# Altium schematic sheet 15-M2_GNSS.SchDoc(15-M2_GNSS)
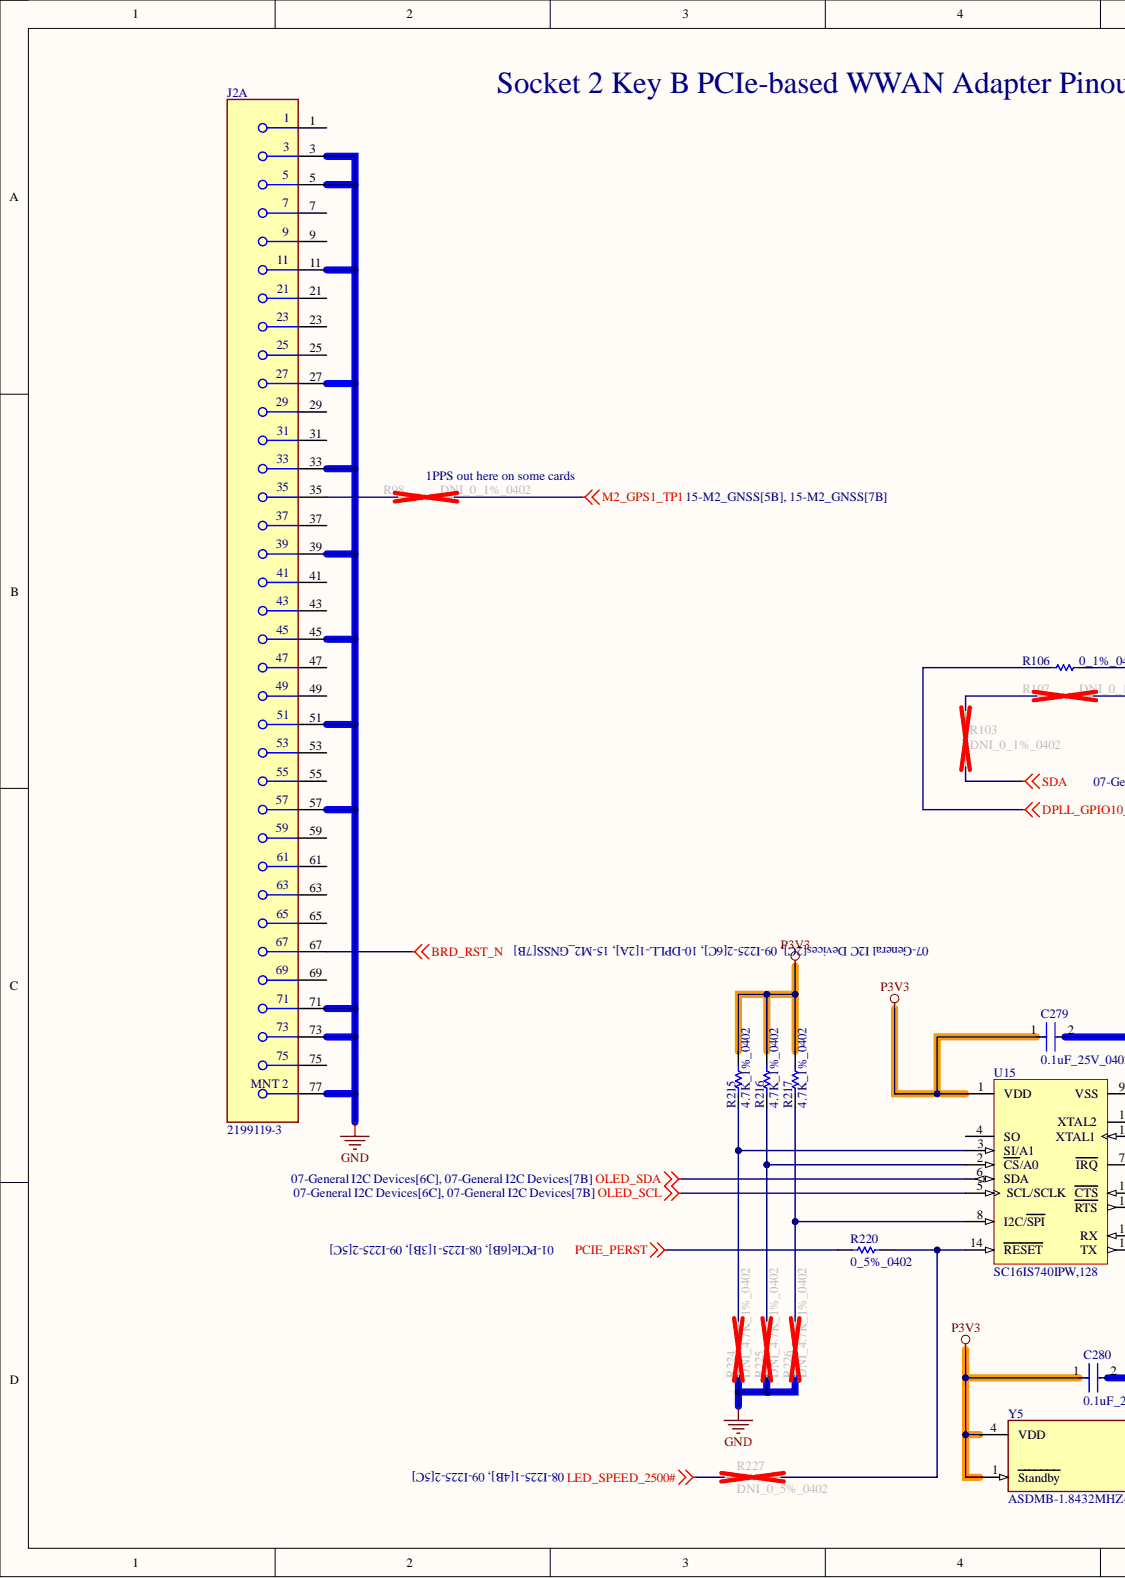
[diagram p0: 15-M2_GNSS.SchDoc, left]
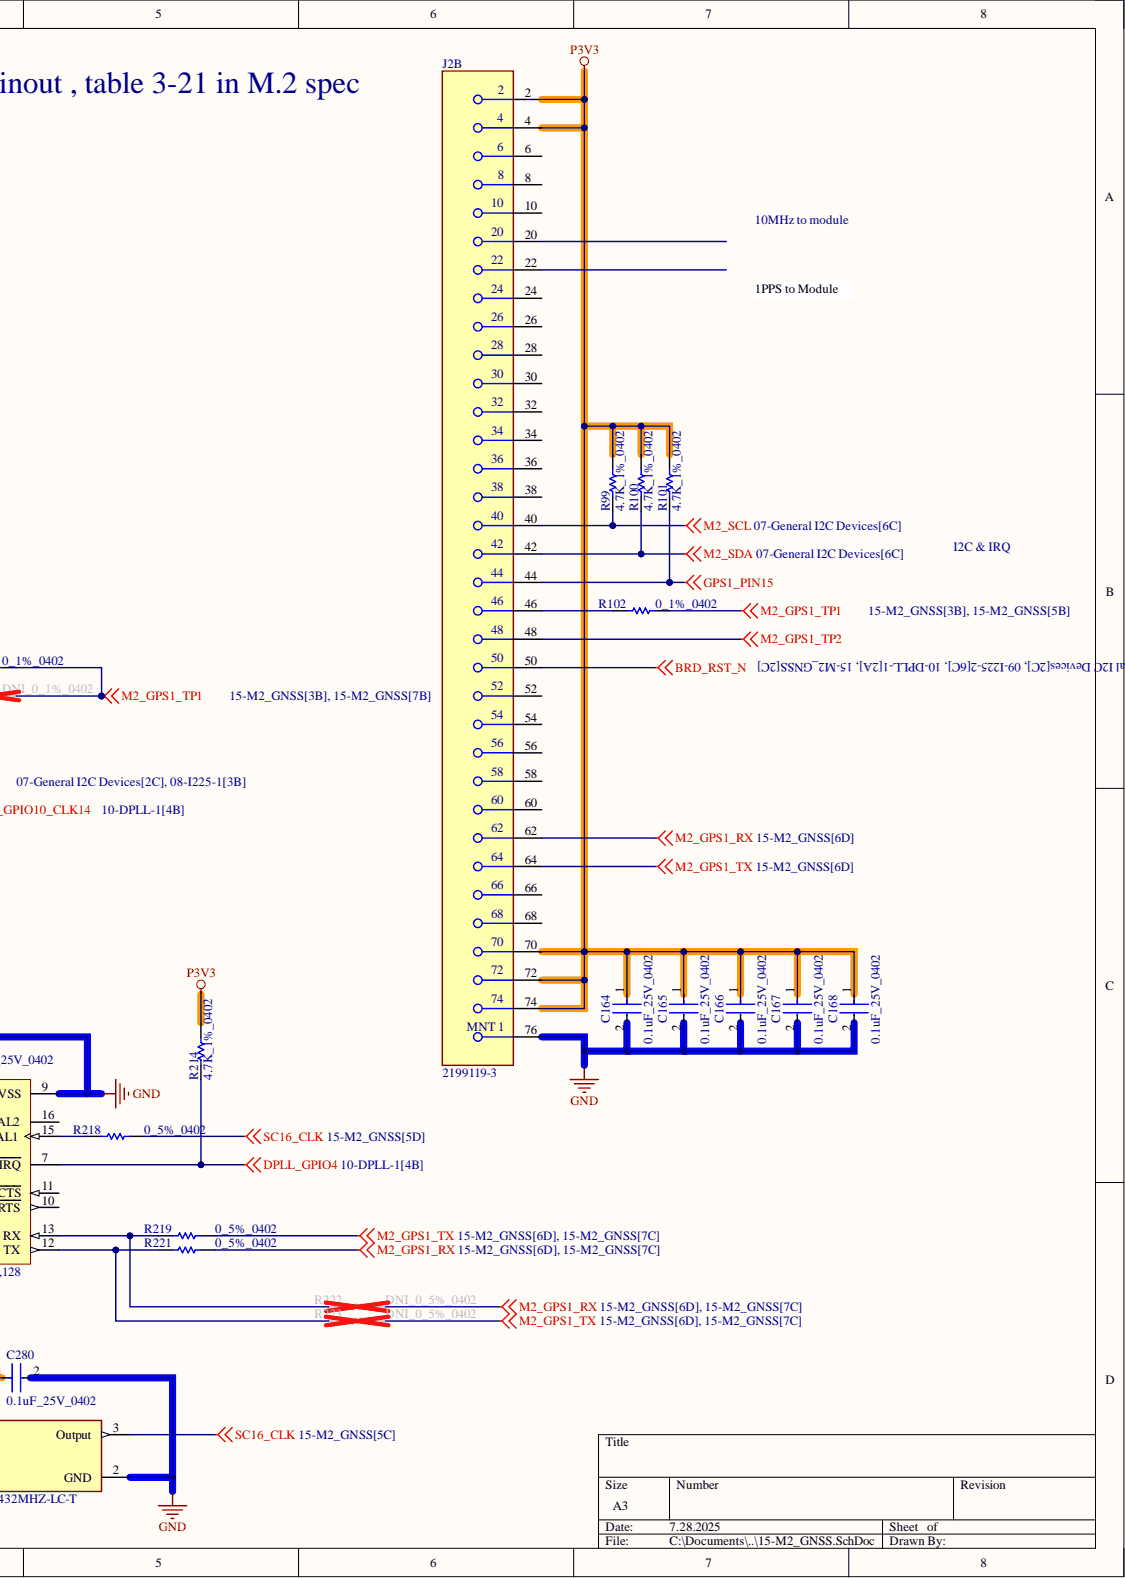
[diagram p1: 15-M2_GNSS.SchDoc, right]

## Parts
C164  0.1uF_25V_0402  [FP-0402-L_1_0_1-W_0_5_0_1-IPC_C]
C165  0.1uF_25V_0402  [FP-0402-L_1_0_1-W_0_5_0_1-IPC_C]
C166  0.1uF_25V_0402  [FP-0402-L_1_0_1-W_0_5_0_1-IPC_C]
C167  0.1uF_25V_0402  [FP-0402-L_1_0_1-W_0_5_0_1-IPC_C]
C168  0.1uF_25V_0402  [FP-0402-L_1_0_1-W_0_5_0_1-IPC_C]
C279  0.1uF_25V_0402  [FP-0402-L_1_0_1-W_0_5_0_1-IPC_C]
C280  0.1uF_25V_0402  [FP-0402-L_1_0_1-W_0_5_0_1-IPC_C]
J2  2199119-3  [FP-2199119-3-MFG]  (parts A,B)
R98  DNI_0_1%_0402  [RESC1005X40X25LL05T05]  (no BOM)
R99  4.7K_1%_0402  [RESC1005X40X25NL10T10]
R100  4.7K_1%_0402  [RESC1005X40X25NL10T10]
R101  4.7K_1%_0402  [RESC1005X40X25NL10T10]
R102  0_1%_0402  [RESC1005X40X25LL05T05]
R103  DNI_0_1%_0402  [RESC1005X40X25LL05T05]  (no BOM)
R106  0_1%_0402  [RESC1005X40X25LL05T05]
R107  DNI_0_1%_0402  [RESC1005X40X25LL05T05]  (no BOM)
R214  4.7K_1%_0402  [RESC1005X40X25NL10T10]
R215  4.7K_1%_0402  [RESC1005X40X25NL10T10]
R216  4.7K_1%_0402  [RESC1005X40X25NL10T10]
R217  4.7K_1%_0402  [RESC1005X40X25NL10T10]
R218  0_5%_0402  [RESC1005X40X25LL10T10]
R219  0_5%_0402  [RESC1005X40X25LL10T10]
R220  0_5%_0402  [RESC1005X40X25LL10T10]
R221  0_5%_0402  [RESC1005X40X25LL10T10]
R222  DNI_0_5%_0402  [RESC1005X40X25LL10T10]  (no BOM)
R223  DNI_0_5%_0402  [RESC1005X40X25LL10T10]  (no BOM)
R224  DNI_4.7K_1%_0402  [RESC1005X40X25NL10T10]  (no BOM)
R225  DNI_4.7K_1%_0402  [RESC1005X40X25NL10T10]  (no BOM)
R226  DNI_4.7K_1%_0402  [RESC1005X40X25NL10T10]  (no BOM)
R227  DNI_0_5%_0402  [RESC1005X40X25LL10T10]  (no BOM)
U15  SC16IS740IPW,128  [NXP-SOT403-1_V]
Y5  ASDMB-1.8432MHZ-LC-T  [FP-ASDM-ASDMB-MFG]

## Nets
BRD_RST_N: J2.50 J2.67
DPLL_GPIO4: R214.1 U15.7(IRQ)
DPLL_GPIO10_CLK14: R106.1
GND: C164.2 C165.2 C166.2 C167.2 C168.2 C279.2 C280.2 J2.3 J2.5 J2.11 J2.27 J2.33 J2.39 J2.45 J2.51 J2.57 J2.71 J2.73 J2.76 J2.77 R224.1 R225.1 R226.1 U15.9(VSS) Y5.2(GND)
GPS1_PIN15: J2.44 R101.1
LED_SPEED_2500#: R227.2
M2_GPS1_RX: J2.62 R221.1 R222.1
M2_GPS1_TP1: R98.2 R102.2 R106.2 R107.2
M2_GPS1_TP2: J2.48
M2_GPS1_TX: J2.64 R219.1 R223.1
M2_SCL: J2.40 R99.1
M2_SDA: J2.42 R100.1
OLED_SCL: U15.5(SCL/SCLK)
OLED_SDA: U15.6(SDA)
P3V3: C164.1 C165.1 C166.1 C167.1 C168.1 C279.1 C280.1 J2.2 J2.4 J2.70 J2.72 J2.74 R99.2 R100.2 R101.2 R214.2 R215.2 R216.2 R217.2 U15.1(VDD) Y5.1(Standby) Y5.4(VDD)
PCIE_PERST: R220.2
SC16_CLK: R218.1 Y5.3(Output)
SDA: R103.1
NetJ2_35: J2.35 R98.1
NetJ2_46: J2.46 R102.1
NetR103_2: R103.2 R107.1
NetR215_1: R215.1 R224.2 U15.3(SI/A1)
NetR216_1: R216.1 R225.2 U15.2(CS/A0)
NetR217_1: R217.1 R226.2 U15.8(I2C/SPI)
NetR218_2: R218.2 U15.15(XTAL1)
NetR219_2: R219.2 R222.2 U15.13(RX)
NetR220_1: R220.1 R227.1 U15.14(RESET)
NetR221_2: R221.2 R223.2 U15.12(TX)

Not connected: J2 1 6 7 8 9 10 20 21 22 23 24 25 26 28 29 30 31 32 34 36 37 38 41 43 47 49 52 53 54 55 56 58 59 60 61 63 65 66 68 69 75; U15 4(SO) 10(RTS) 11(CTS) 16(XTAL2)

## Library data of the parts
C164-C168 C279 C280 = CMP-2008-02519-2: General Purpose Ceramic Capacitor, 0402, 100nF, 10%, X7R, 15%, 25V; Capacitance: 100nF; Case Code (Imperial): 0402; Case Code (Metric): 1005; Case/Package: 0402; Component Kind: Standard; Construction: Flat; Depth: 0.5mm; Dielectric: X7R; Lead Free: Lead Free; Length: 1mm; Library Name: Altium Content Vault; Max Operating Temperature: 125°C; Min Operating Temperature: -55°C; Mount: Surface Mount; Number of Pins: 2; Package Quantity: 1; Packaging: Tape and Reel; Radiation Hardening: No; REACH SVHC: No SVHC; RoHS Compliant: Yes; Series: -; Termination: SMD/SMT; Thickness: 0.022inch; Tolerance: 10%; Voltage: 25V; Voltage Rating: 25V; Voltage Rating (DC): 25V; Width: 0.02inch
J2 = CMP-03365-000004-1: CONN M.2 FEMALE 67POS 0.020 GOLD; Contact Current Rating: 500mA; Insulation Resistance: 500MR; Max Voltage Rating (AC): 50V; Number of Contacts: 67; PCB Mounting Orientation: Right Angle; Pitch: 0.5mm; Row Spacing: 7.55mm; Color: Black; Component Kind: Standard; Contact Material: Copper Alloy; Contact Plating: Gold; Current Rating: 500mA; ELV: Compliant; Gender: Female; Height: 3.2mm; Housing Color: Black; Housing Material: Thermoplastic; Insulation Material: Thermoplastic; Length: 0.862inch; Library Name: Altium Content Vault; Max Operating Temperature: 80°C; Min Operating Temperature: -40°C; Mount: Surface Mount; Number of Positions: 67; Number of Rows: 2; Orientation: Right Angle; Package Quantity: 1200; Packaging: Tape and Reel; Radiation Hardening: No; RoHS Compliant: Yes; Termination: Solder; Voltage Rating: 50V; Width: 8.7mm
R98 R102 R103 R106 R107 = CMP-2000-07451-1: Chip Resistor, 0 Ohm, 0.1 W, -55 to 155 degC, 0402 (1005 Metric), RoHS, Tape and Reel; Manufacturer: Panasonic; Max Power Dissipation: 100mW; Resistance: 0mOhm; Temperature Coefficient: 600ppm/��C; Tolerance: 5%; Voltage Rating: 50V; Case Code (Imperial): 0402; Case Code (Metric): 1005; Case/Package: 0402; Composition: ThickFilm; Contact Plating: Tin; Datasheet URL: https://industrial.panasonic.com/cdbs/www-data/pdf/RDA0000/AOA0000C301.pdf; Datasheet Version: 03/2015; Depth: 0.5mm; Height: 0.35mm; Lead Free: LeadFree; Length: 1mm; Library Name: Altium Content Vault; Manufacturer URL: http://www.panasonic.com/; Max Operating Temperature: 155degC; Military Standard: Not; Min Operating Temperature: -55degC; Mount: SurfaceMount; Mounting Technology: SurfaceMount; Number of Terminations: 2; Package Description: 2-Pin Surface Mount Device, Body 1 x 0.5 mm; Package Quantity: 1; Package Reference: 402; Packaging: TapeandReel; Power Rating: 100mW; Radiation Hardening: No; REACH SVHC: NoSVHC; RoHSCompliant: True; Termination: SMD/SMT; Voltage Rating (DC): 50V; Width: 0.02inch
  R102 R106: Component Kind: Standard
R99-R101 R214-R217 R224-R226 = CMP-2002-05217-1: Manufacturer: Bourns; Part Number: CR0402-FX-4701GLF; Library Name: Altium Content Vault
  R99-R101 R214-R217: Component Kind: Standard
R218-R223 R227 = CMP-2002-04569-1: Manufacturer: KOA Speer; Part Number: RK73Z1ETTP; Library Name: Altium Content Vault
  R218-R221: Component Kind: Standard
U15 = CMP-1651-00006-1: Single UART with I2C-Bus/SPI Interface, 64 Bytes of Transmit and Receive FIFOs, IrDA SIR Built-in Support, 2.3 to 3.6 V, -40 to 95 degC, 16-Pin TSSOP (SOT403-1), RoHS, Tape and Reel; Data Rate: 5 Mbps; Supply Current Max: 6 mA; Supply Voltage Max: 3.6 V; Supply Voltage Min: 2.3 V; Code_JEDEC: MO-153; Component Kind: Standard; ComponentLink1Description: Manufacturer URL; ComponentLink1URL: http://www.nxp.com/; ComponentLink2Description: Datasheet; ComponentLink2URL: http://cache.nxp.com/documents/data_sheet/SC16IS740_750_760.pdf?pspll=1; ComponentLink3Description: Package Specification; ComponentLink3URL: http://www.nxp.com/documents/outline_drawing/sot403-1_po.pdf; DatasheetVersion: Rev. 7; Generic Part Number: SC16IS740; Library Name: Altium Content Vault; Mounting Technology: Surface Mount; PackageDescription: 16-Pin Plastic Thin Shrink Small Outline Package, Body 5 x 4.4 mm, Pitch 0.65 mm; PackageVersion: 03-02-18, 02/2003; Packing: Tape and Reel; PartNumber: SC16IS740IPW,128; RoHS: TRUE; Temperature Max: 95 degC; Temperature Min: -40 degC
Y5 = CMP-27762-001563-1: MEMS Oscillator 1.8432MHz ±50ppm LVCMOS 1.8V~3.3V SMD 2.5x2mm; Load Capacitance: 15pF; Max Supply Current: 16mA; Max Supply Voltage: 4V; Min Supply Voltage: -300mV; Operating Supply Voltage: 3.3V; Case/Package: SMD/SMT; Component Kind: Standard; Frequency: 1.8432MHz; Frequency Stability: 50ppm; Height: 0.035inch; Length: 0.098inch; Library Name: Altium Content Vault; Max Operating Temperature: 85°C; Min Operating Temperature: -40°C; Mount: Surface Mount; Number of Pins: 4; Packaging: Tape and Reel; RoHS Compliant: Yes; Series: Pure Silicon™ ASDMB; Termination: SMD/SMT; Width: 0.079inch
